# Altium schematic sheet Rajesh_Jasti_17978253_Lab_1.SchDoc(Rajesh_Jasti_17978253_Lab_1)
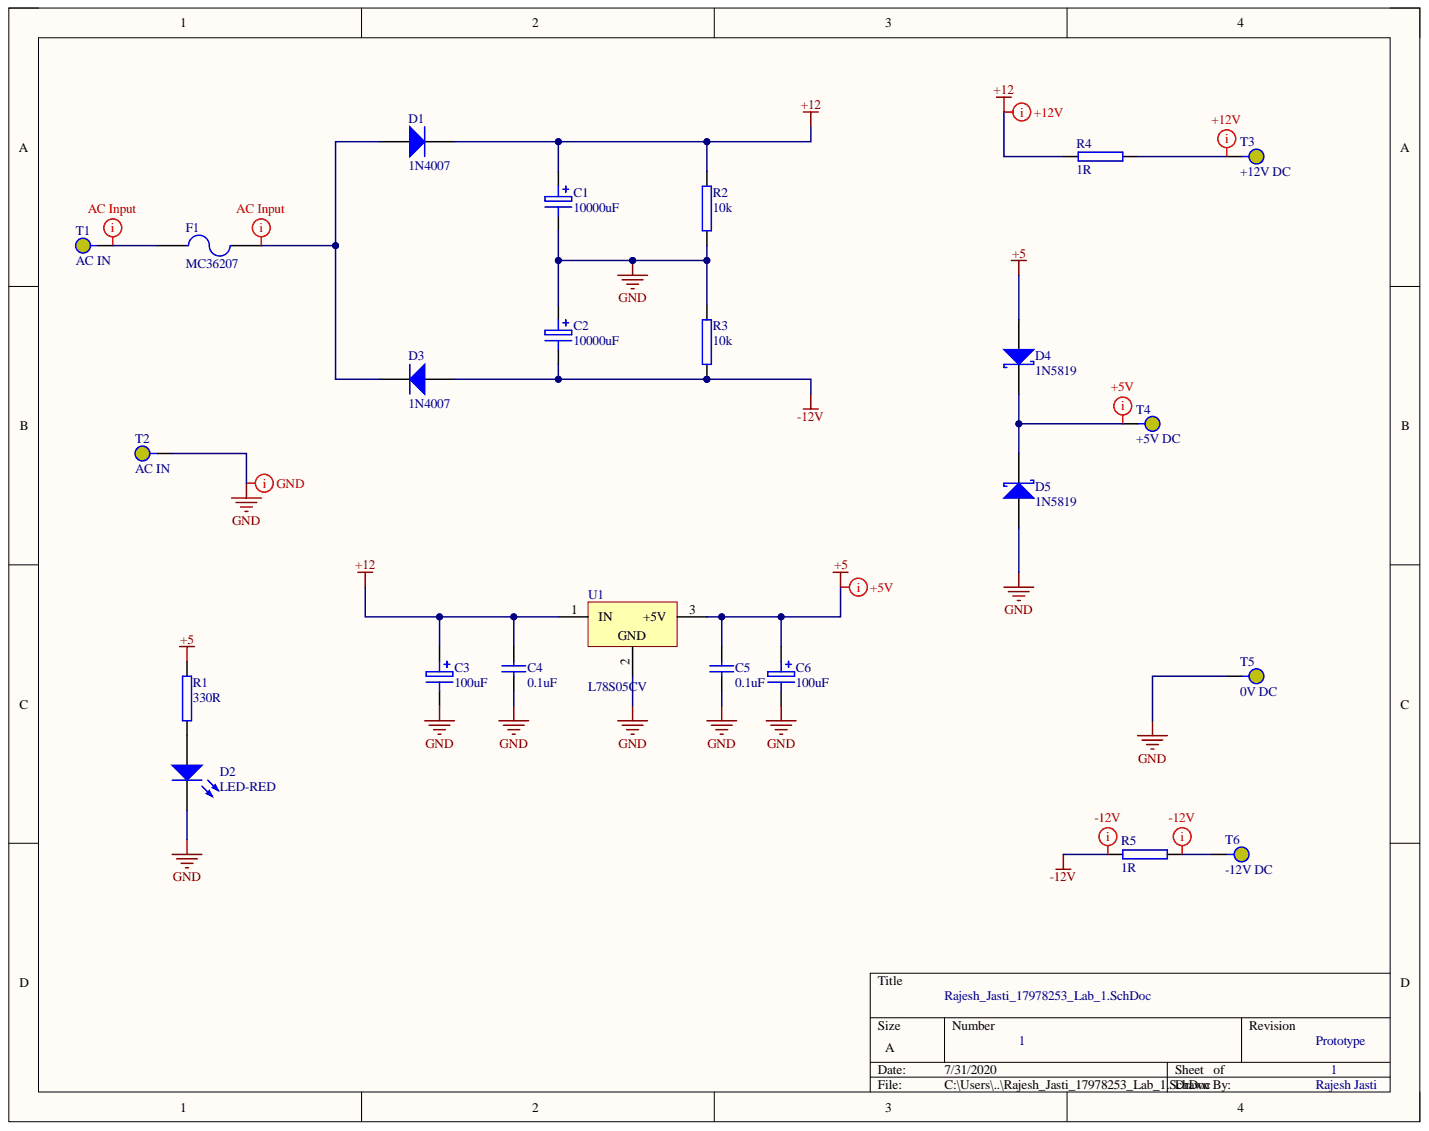
[diagram p0: Rajesh_Jasti_17978253_Lab_1.SchDoc]

## Parts
C1  10000uF  [CAPP18-7.5mm]
C2  10000uF  [CAPP18-7.5mm]
C3  100uF  [CAPP150-300_AUT]
C4  0.1uF  [RAD-0.1_AUT]
C5  0.1uF  [RAD-0.1_AUT]
C6  100uF  [CAPP150-300_AUT]
D1  1N4007  [DIODE0.4_AUT]
D2  LED-RED  [LED3MM_R_AUT]
D3  1N4007  [DIODE0.4_AUT]
D4  1N5819  [DIODE0.4_AUT]
D5  1N5819  [DIODE0.4_AUT]
F1  MC36207  [MC36207]
R1  330R  [AXIAL-0.4AUT]
R2  10k  [AXIAL-0.4AUT]
R3  10k  [AXIAL-0.4AUT]
R4  1R  [AXIAL-0.5AUT]
R5  1R  [AXIAL-0.5AUT]
T1  AC IN  [PAD3_AUT]
T2  AC IN  [PAD3_AUT]
T3  +12V DC  [PAD3_AUT]
T4  +5V DC  [PAD3_AUT]
T5  0V DC  [PAD3_AUT]
T6  -12V DC  [PAD3_AUT]
U1  L78S05CV  [TO-220H]

## Nets
+5: C5.2 C6.1 D4.A R1.2 U1.3
+12: C1.1 C3.1 C4.2 D1.K R2.2 R4.1 U1.1
-12V: C2.2 D3.A R3.1 R5.1
GND: C1.2 C2.1 C3.2 C4.1 C5.1 C6.2 D2.K D5.A R2.1 R3.2 T2.1 T5.1 U1.2
NetD1_A: D1.A D3.K F1.2
NetD2_A: D2.A R1.1
NetD4_K: D4.K D5.K T4.1
NetF1_1: F1.1 T1.1
NetR4_2: R4.2 T3.1
NetR5_2: R5.2 T6.1

## Library data of the parts
C1 C2 = CAPACITOR 10000uF: Polarized Aluminum Capacitor, Through Hole, Pitch 7.5mml, Body 18mm(Dia); Component Kind: Standard; Library Name: Resistors and Capacitors.IntLib; Supplier 1: Farnell; Supplier Part Number 1: 1899587
C3 C6 = CAPP150-300: Polarized Aluminum Capacitor, Through Hole, Pitch 150mil, Body 300mil (Dia); Component Kind: Standard; Library Name: Resistors and Capacitors.IntLib
C4 C5 = CAPN RAD0.1: Non Polarized Capacitor, Multilayer Ceramic Dipped Radial, Pitch 100mil; Component Kind: Standard; Library Name: Resistors and Capacitors.IntLib
D1 D3 = 1N4007: Diode, Standard, 1A, 1000v; Component Kind: Standard; Library Name: Diodes.IntLib; Supplier 1: Farnell; Supplier Part Number 1: 9549129
D2 = 3mm LED-RED: 3mm RED LED, Through Hole; Component Kind: Standard; Library Name: LEDs.IntLib; Supplier 1: Farnell; Supplier Part Number 1: 1003207
D4 D5 = 1N5819: Schottky Diode, 1A,40v; Component Kind: Standard; Library Name: Diodes.IntLib; Supplier 1: Farnell; Supplier Part Number 1: 1017589
F1 = MC36207: Fuse, PTC Reset, 200mA Hold, 400mA Tripping, 30v; Component Kind: Standard; Library Name: Fuses.IntLib; Supplier 1: Farnell; Supplier Part Number 1: 2366765
R1-R3 = Resistor 1/4W: Resistor, Through Hole, Pitch 400mil; Component Kind: Standard; Library Name: Resistors and Capacitors.IntLib
R4 R5 = Resistor 1/2W: Resistor, Through Hole, Pitch 500mil; Component Kind: Standard; Library Name: Resistors and Capacitors.IntLib
T1-T6 = Terminal3: Terminal, Pad 200x250mil, Hole 62mil; Component Kind: Standard; Library Name: Connectors.IntLib
U1 = L78S05CV: 5V, 2A, LDO, TO-220-3; Component Kind: Standard; Library Name: Power Management.IntLib; Supplier 1: Farnell; Supplier Part Number 1: 9756086
